# Altium schematic sheet Ultrasonic_TX&RX.SchDoc(Ultrasonic_TX&RX)
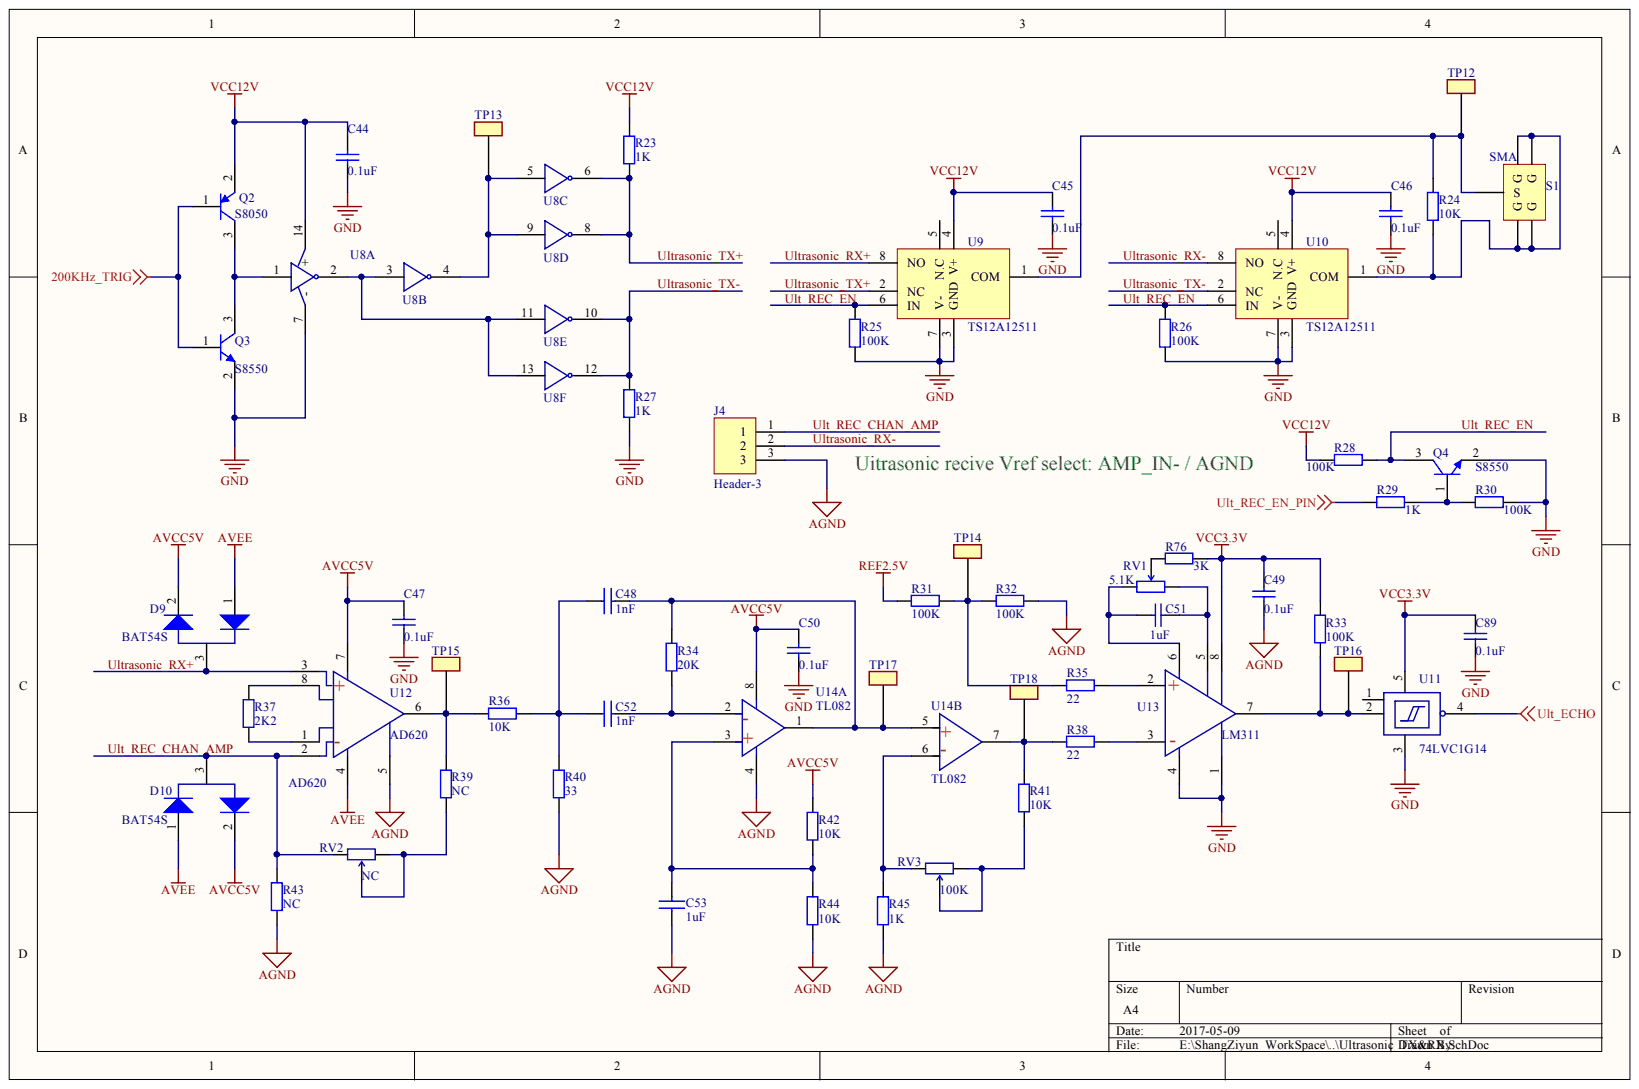
[diagram p0: Ultrasonic_TX&RX.SchDoc]

## Parts
C44  value 0.1uF  [0603C]  (hidden comment: Capacitor)
C45  value 0.1uF  [0603C]  (hidden comment: Capacitor)
C46  value 0.1uF  [0603C]  (hidden comment: Capacitor)
C47  value 0.1uF  [0603C]  (hidden comment: Capacitor)
C48  value 1nF  [0603C]  (hidden comment: CAP NP)
C49  value 0.1uF  [0603C]  (hidden comment: Capacitor)
C50  value 0.1uF  [0603C]  (hidden comment: Capacitor)
C51  value 1uF  [0603C]  (hidden comment: Capacitor)
C52  value 1nF  [0603C]  (hidden comment: CAP NP)
C53  value 1uF  [0603C]  (hidden comment: CAP NP)
C89  value 0.1uF  [0603C]  (hidden comment: Capacitor)
D9  BAT54S  [SOT23]
D10  BAT54S  [SOT23]
J4  Header3  value Header-3  [SIP3]
Q2  value S8050  [SOT23]  (hidden comment: PNP)
Q3  Q?  value S8550  [SOT23]
Q4  Q?  value S8550  [SOT23]
R23  value 1K  [L0603]  (hidden comment: Resistor)
R24  value 10K  [L0603]  (hidden comment: Resistor)
R25  value 100K  [L0603]  (hidden comment: Resistor)
R26  value 100K  [L0603]  (hidden comment: Resistor)
R27  value 1K  [L0603]  (hidden comment: Resistor)
R28  value 100K  [L0603]  (hidden comment: Resistor)
R29  value 1K  [L0603]  (hidden comment: Resistor)
R30  value 100K  [L0603]  (hidden comment: Resistor)
R31  value 100K  [L0603]  (hidden comment: Resistor)
R32  value 100K  [L0603]  (hidden comment: Resistor)
R33  value 100K  [L0603]  (hidden comment: Resistor)
R34  value 20K  [L0603]  (hidden comment: Resistor)
R35  value 22  [L0603]  (hidden comment: Resistor)
R36  value 10K  [L0603]  (hidden comment: Resistor)
R37  value 2K2  [L0603]  (hidden comment: Resistor)
R38  value 22  [L0603]  (hidden comment: Resistor)
R39  value NC  [L0603]  (hidden comment: Resistor)
R40  value 33  [L0603]  (hidden comment: Resistor)
R41  value 10K  [L0603]  (hidden comment: Resistor)
R42  value 10K  [L0603]  (hidden comment: Resistor)
R43  value NC  [L0603]  (hidden comment: Resistor)
R44  value 10K  [L0603]  (hidden comment: Resistor)
R45  value 1K  [L0603]  (hidden comment: Resistor)
R76  value 3K  [L0603]  (hidden comment: Resistor)
RV1  value 5.1K  [EVM3GSX50B14]  (hidden comment: POT-HG)
RV2  value NC  [3396]  (hidden comment: POT-HG)
RV3  value 100K  [3396]  (hidden comment: POT-HG)
S1  SMA  [SMA-KWE]
TP12  TEST POINT_0  [TP]  (value not drawn)
TP13  TEST POINT_0  [TP]  (value not drawn)
TP14  TEST POINT_0  [TP]  (value not drawn)
TP15  TEST POINT_0  [TP]  (value not drawn)
TP16  TEST POINT_0  [TP]  (value not drawn)
TP17  TEST POINT_0  [TP]  (value not drawn)
TP18  TEST POINT_0  [TP]  (value not drawn)
U8  CD4069  [SOP14]  (value not drawn)  (parts A,B,C,D,E,F)
U9  TS12A12511  [SOT23-8]
U10  TS12A12511  [SOT23-8]
U11  74LVC1G14  [SOT23-5]
U12  AD620  [SOP8]
U13  LM311  [SOP8]
U14  TL082  [SOP8]  (parts A,B)

## Nets
200KHZ_TRIG: Q2.1 Q3.1
AGND: C49.2 C53.1 J4.3 R32.1 R40.1 R43.1 R44.2 R45.1 U12.5 U14.4
AVCC5V: C47.1 C50.1 D9.2 D10.2 R42.1 U12.7 U14.8
AVEE: D9.1 D10.1 U12.4
GND: C44.2 C45.2 C46.2 C47.2 C50.2 C89.2 Q3.2 Q4.2 R25.2 R26.2 R27.2 R30.2 U8.7(-) U9.3(GND) U9.7(V-) U10.3(GND) U10.7(V-) U11.3 U13.1 U13.4
REF2.5V: R31.2
ULT_ECHO: U11.4
ULT_REC_EN_PIN: R29.2
VCC3.3V: C49.1 C89.1 R33.2 R76.2 U11.5 U13.8
VCC12V: C44.1 C45.1 C46.1 Q2.2 R23.1 R28.1 U8.14(+) U9.4(V+) U10.4(V+)
ULT_REC_CHAN_AMP: D10.3 J4.1 R43.2 RV2.3 U12.2
ULT_REC_EN: Q4.3 R25.1 R26.1 R28.2 U9.6(IN) U10.6(IN)
ULTRASONIC_RX+: D9.3 U9.8(NO) U12.3
ULTRASONIC_RX-: J4.2 U10.8(NO)
ULTRASONIC_TX+: R23.2 U8.6 U8.8 U9.2(NC)
ULTRASONIC_TX-: R27.1 U8.10 U8.12 U10.2(NC)
NetC48_1: C48.1 C52.1 R36.1 R40.2
NetC48_2: C48.2 R34.1 TP17.1 U14.1 U14.5(+)
NetC51_1: C51.1 RV1.3 U13.5
NetC51_2: C51.2 RV1.1 U13.6
NetC52_2: C52.2 R34.2 U14.2(-)
NetC53_2: C53.2 R42.2 R44.1 U14.3(+)
NetQ2_3: Q2.3 Q3.3 U8.1
NetQ4_1: Q4.1 R29.1 R30.1
NetR24_1: R24.1 S1.5(S) TP12.1 U9.1(COM)
NetR24_2: R24.2 S1.1(G) S1.2(G) S1.3(G) S1.4(G) U10.1(COM)
NetR31_1: R31.1 R32.2 R35.2 TP14.1
NetR33_1: R33.1 TP16.1 U11.2 U13.7
NetR35_1: R35.1 U13.2(+)
NetR36_2: R36.2 R39.2 TP15.1 U12.6
NetR37_1: R37.1 U12.8(+)
NetR37_2: R37.2 U12.1(-)
NetR38_1: R38.1 U13.3(-)
NetR38_2: R38.2 R41.2 TP18.1 U14.7
NetR39_1: R39.1 RV2.1 RV2.2
NetR41_1: R41.1 RV3.1 RV3.2
NetR45_2: R45.2 RV3.3 U14.6(-)
NetR76_1: R76.1 RV1.2
NetTP13_1: TP13.1 U8.4 U8.5 U8.9
NetU8_2: U8.2 U8.3 U8.11 U8.13

Not connected: U9 5(N.C); U10 5(N.C); U11 1

## Library data of the parts
C44-C47 C49-C51 C89 = Cap: Component Kind: Standard; Description: Capacitor; LatestRevisionDate: 17-Jul-2002; LatestRevisionNote: Re-released for DXP Platform.; Library Name: Lib1.SCHLIB; PackageDescription: Radial Cap, Thru-Hole; 2 Leads; 0.3 in Pin Spacing; PackageReference: RAD-0.3; Published: 8-Jun-2000; Signal Integrity: Cap; Simulation: CAP; Publisher: Altium Limited
Q3 Q4 = NPN: Component Kind: Standard; Library Name: MyLib.SCHLIB
R23-R45 R76 = Res: Component Kind: Standard; Library Name: Lib1.SCHLIB
S1 = SMA_5: Component Kind: Standard; Value: SMA; Library Name: LNG.SCHLIB
C48 C52 C53 = CAP NP: Component Kind: Standard; Library Name: MAINBOARD_Library.SchLib
D9 D10 = BAT54S: Component Kind: Standard; Library Name: MyLib.SCHLIB
  D9: : BAT54S
  D10: Value: BAT54S
J4 = Header3: Component Kind: Standard; Library Name: MyLib.SCHLIB
Q2 = PNP: Component Kind: Standard; Library Name: MyLib.SCHLIB
RV1-RV3 = POT-HG: Component Kind: Standard; Library Name: LNG.SCHLIB
TP12-TP18 = TEST POINT_0: Component Kind: Standard; Library Name: MAINBOARD_Library.SchLib
U8 = CD4069: Component Kind: Standard; Library Name: MyLib.SCHLIB; Value: CD4069
U9 U10 = TS12A12511: Component Kind: Standard; Library Name: MyLib.SCHLIB; Value: TS12A12511
U11 = 74LVC1G14: Component Kind: Standard; Library Name: MyLib.SCHLIB; Value: 74LVC1G14
U12 = AD620: Component Kind: Standard; Library Name: MyLib.SCHLIB; Value: AD620
U13 = LM311: Component Kind: Standard; Library Name: MyLib.SCHLIB; Value: LM311
U14 = TL082: Component Kind: Standard; Library Name: MyLib.SCHLIB; Value: TL082
